# Altium schematic sheet IdealDiode 03-1.0 complete.SchDoc
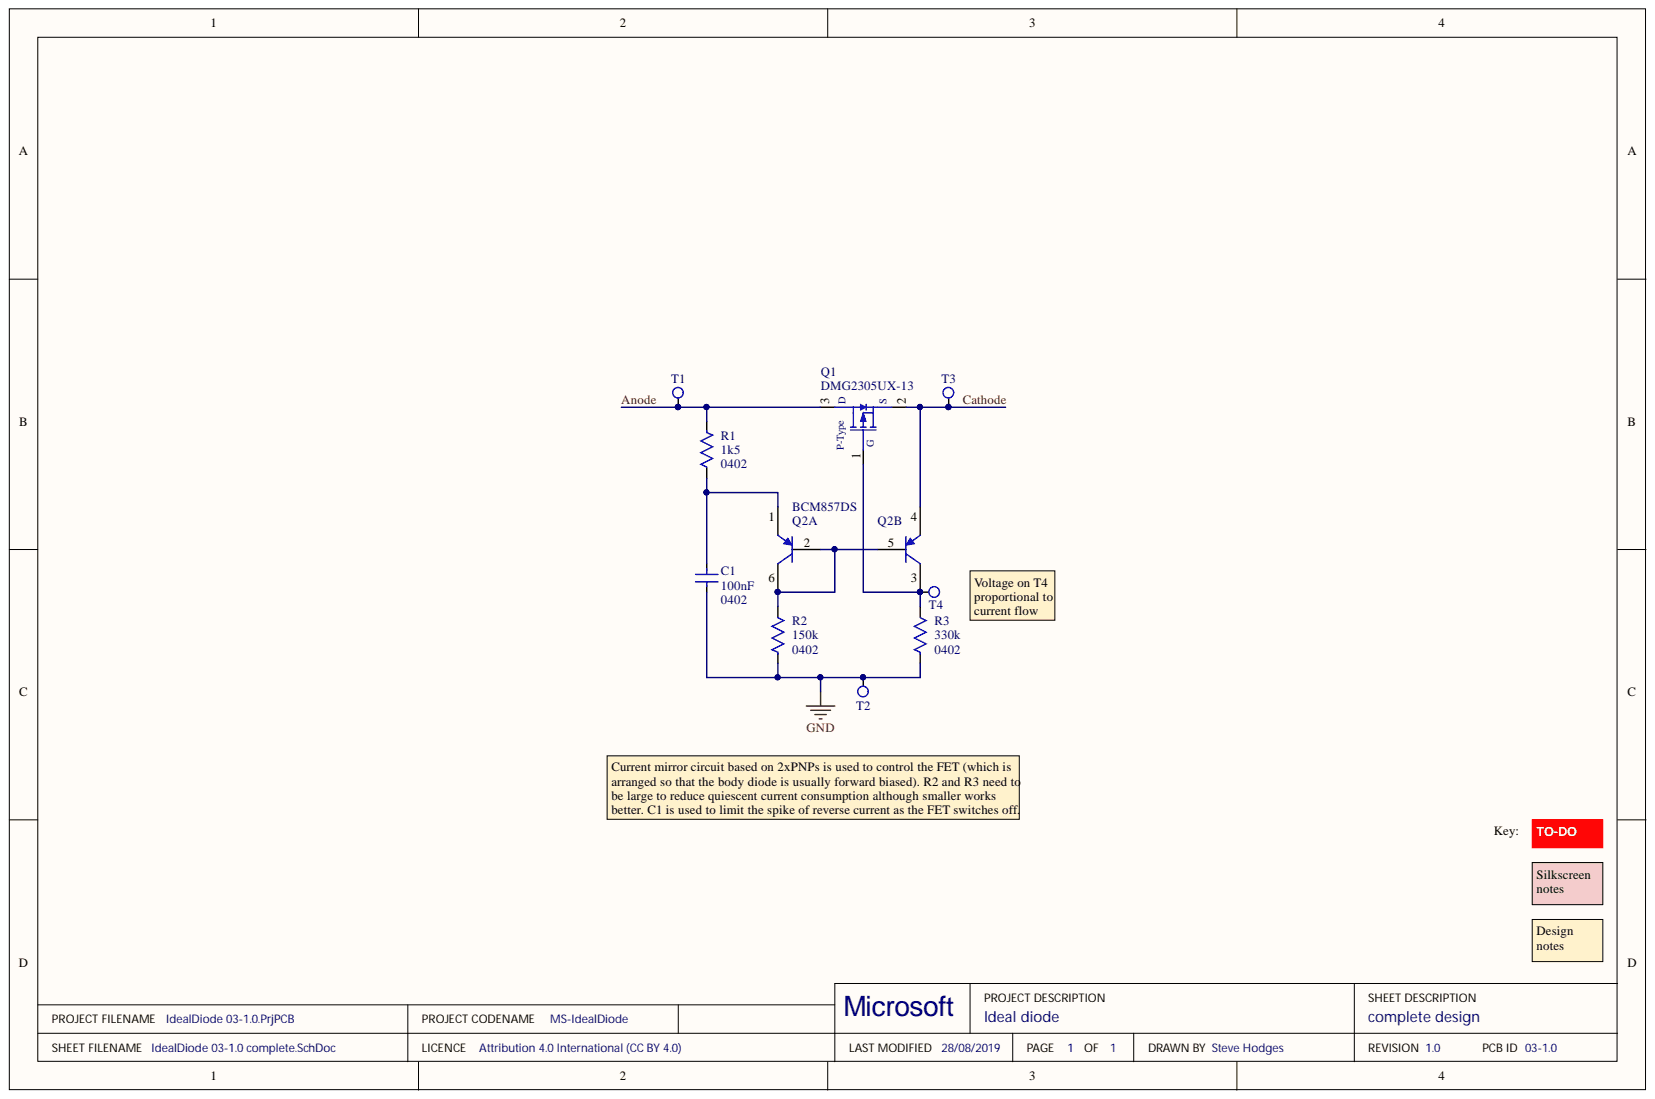
[diagram p0: IdealDiode 03-1.0 complete.SchDoc]

## Parts
C1  Capacitance: 100nF  Case/Package: 0402  [CAP0402]  (hidden comment: 0402YG104ZAT2A)
Q1  Manufacturer Part Number: DMG2305UX-13  [DIODES-SOT23-3]  (hidden comment: MOSFET)
Q2  BCM857DS  [NXP-SOT457]  (parts A,B)
R1  value 1k5  Case: 0402  [RES0402]  (no BOM)
R2  value 150k  Case: 0402  [RES0402]  (no BOM)
R3  value 330k  Case: 0402  [RES0402]  (no BOM)
T1  TestPointHole  [PTH1.5mm-1.0mm]  (value not drawn)  (no BOM)
T2  TestPointHole  [PTH1.5mm-1.0mm]  (value not drawn)  (no BOM)
T3  TestPointHole  [PTH1.5mm-1.0mm]  (value not drawn)  (no BOM)
T4  TestPoint  [Pad1mm]  (value not drawn)  (no BOM)

## Nets
GND: C1.1 R2.2 R3.2 T2.1
Anode: Q1.3 R1.2 T1.1
Cathode: Q1.2 Q2.4 T3.1
NetC1_2: C1.2 Q2.1 R1.1
NetQ1_1: Q1.1 Q2.3 R3.1 T4.1
NetQ2_2: Q2.2 Q2.5 Q2.6 R2.1

## Library data of the parts
C1 = 0402YG104ZAT2A: 100nF 0402 16V; Manufacturer: Kyocera AVX; Dielectric: Y5V; Dissipation Factor: 9%; Insulation Resistance: 100GR; Tolerance: 80%; Case Code (Imperial): 0402; Case Code (Metric): 1005; Component Kind: Standard; ComponentLink2Description: AVX datasheet online; ComponentLink2URL: http://datasheets.avx.com/Y5V.pdf; Contact Plating: Tin,Matte; Depth: 0.5mm; Height: 0.5mm; Lead Free: Lead Free; Length: 1mm; Library Name: AVX.SchLib; Manufacturer Part Number: 0402YG104ZAT2A; Max Operating Temperature: 85°C; Min Operating Temperature: -30°C; Mount: Surface Mount,PCB; Package Quantity: 1; Packaging: Tape and Reel; Pricing 1: 1=0.1, 10=0.041, 100=0.0183, 500=0.01306, 1000=0.01026, 2500=0.00933, 5000=0.00858 (USD); Radiation Hardening: No; REACH SVHC: No SVHC; Stock 1: 0; Supplier 1: Digi-Key; Supplier 2: Farnell; Supplier 3: Mouser; Supplier 4: RSComponents; Supplier Part Number 1: 478-1138-1-ND; Supplier Part Number 2: 1327667; Supplier Part Number 3: 581-040216G104Z; Supplier Part Number 4: 698-3190; Termination: SMD/SMT; Thickness: 0.022inch; Voltage: 16V; Voltage Rating: 16V; Voltage Rating (DC): 16V; Width: 0.02inch
Q1 = DMG2305UX-whitesch: MOSFET P-CH 20V 4.2A SOT23; Manufacturer: Diodes; Drain to Source Breakdown Voltage: -20V; Drain to Source Resistance: 52mR; Element Configuration: Single; Fall Time: 34.7ns; Gate to Source Voltage (Vgs): 8V; Input Capacitance: 808pF; Max Power Dissipation: 1.4W; Number of Channels: 1; On-State Resistance: 65MR; Rise Time: 13.7ns; Threshold Voltage: -900mV; Turn-Off Delay Time: 79.3ns; Turn-On Delay Time: 10.8ns; Case/Package: TO-236-3; Component Kind: Standard; ComponentLink2Description: online datasheet; ComponentLink2URL: https://datasheet.ciiva.com/18566/dmg2305ux-18566951.pdf; ComponentLink3Description: datasheet; Continuous Drain Current (ID): 4.2A; Drain to Source Voltage (Vdss): 20V; Height: 1.1mm; Lead Free: Lead Free; Length: 3mm; Library Name: DiodesInc.SchLib; Max Operating Temperature: 150°C; Min Operating Temperature: -55°C; Mount: Surface Mount; Number of Pins: 3; Package Quantity: 1; Packaging: Tape and Reel; Pricing 1: 1=0.44, 10=0.398, 100=0.2228, 500=0.1194, 1000=0.0812 (USD); Radiation Hardening: No; Rds On Max: 52mR; REACH SVHC: No SVHC; Stock 1: 131638; Supplier 1: Digi-Key; Supplier 2: Farnell; Supplier 3: Mouser; Supplier 4: RSComponents; Supplier Part Number 1: DMG2305UX-13DICT-ND; Supplier Part Number 2: 2543533; Supplier Part Number 3: 621-DMG2305UX-7; Supplier Part Number 4: 8270452; Weight: 0.000282oz; Width: 1.4mm; ComponentLink3URL: Y:\System\Altium\Libraries 2014\Diodes Inc\Datasheets\dmg2305ux-30023840.pdf
Q2 = BCM857DS,115-whitesch: BJT ARRAY, DUAL PNP, -45V, -100MA, 6-SOT-457; Manufacturer: NXP Semiconductors; Collector Base Voltage (VCBO): 50V; Collector Emitter Breakdown Voltage: 45V; Emitter Base Voltage (VEBO): 5V; Frequency: 175MHz; Max Collector Current: 100mA; Max Power Dissipation: 380mW; Number of Elements: 2; Test Current: 5mA; Tolerance: 5%; Zener Voltage: 3.3V; Case/Package: SOT-457; Collector Emitter Voltage (VCEO): 45V; Component Kind: Standard; ComponentLink1Description: online datasheet; ComponentLink1URL: https://assets.nexperia.com/documents/data-sheet/BCM857BV_BS_DS.pdf; Contact Plating: Tin; Element Configuration: Dual; Gain Bandwidth Product: 175MHz; Height: 0.25inch; Lead Free: Lead Free; Length: 0.25inch; Library Name: NXP.SchLib; Manufacturer Part Number: BCM857DS,115; Max Breakdown Voltage: 45V; Max Operating Temperature: 150°C; Min Operating Temperature: -65°C; Mount: Surface Mount; Number of Pins: 6; Package Quantity: 1; Packaging: Tape and Reel; Polarity: PNP; Power Dissipation: 380mW; Pricing 1: 1=0.46, 10=0.343, 100=0.2137, 500=0.1462, 1000=0.1125 (USD); Radiation Hardening: No; REACH SVHC: No SVHC; Stock 1: 369; Supplier 1: Digi-Key; Supplier Part Number 1: 1727-4884-1-ND; Transition Frequency: 175MHz; Weight: 0.01lb; Width: 0.25inch
R1-R3 = RES0402_GENERIC: Generic 0402 Chip Resistor; Library Name: generic-res.SchLib
T1-T3 = TestPointHole: Test Point With Hole; Library Name: generic.SchLib
T4 = TestPoint: Test Point; Library Name: generic.SchLib
